# Altium schematic sheet cpu.SchDoc
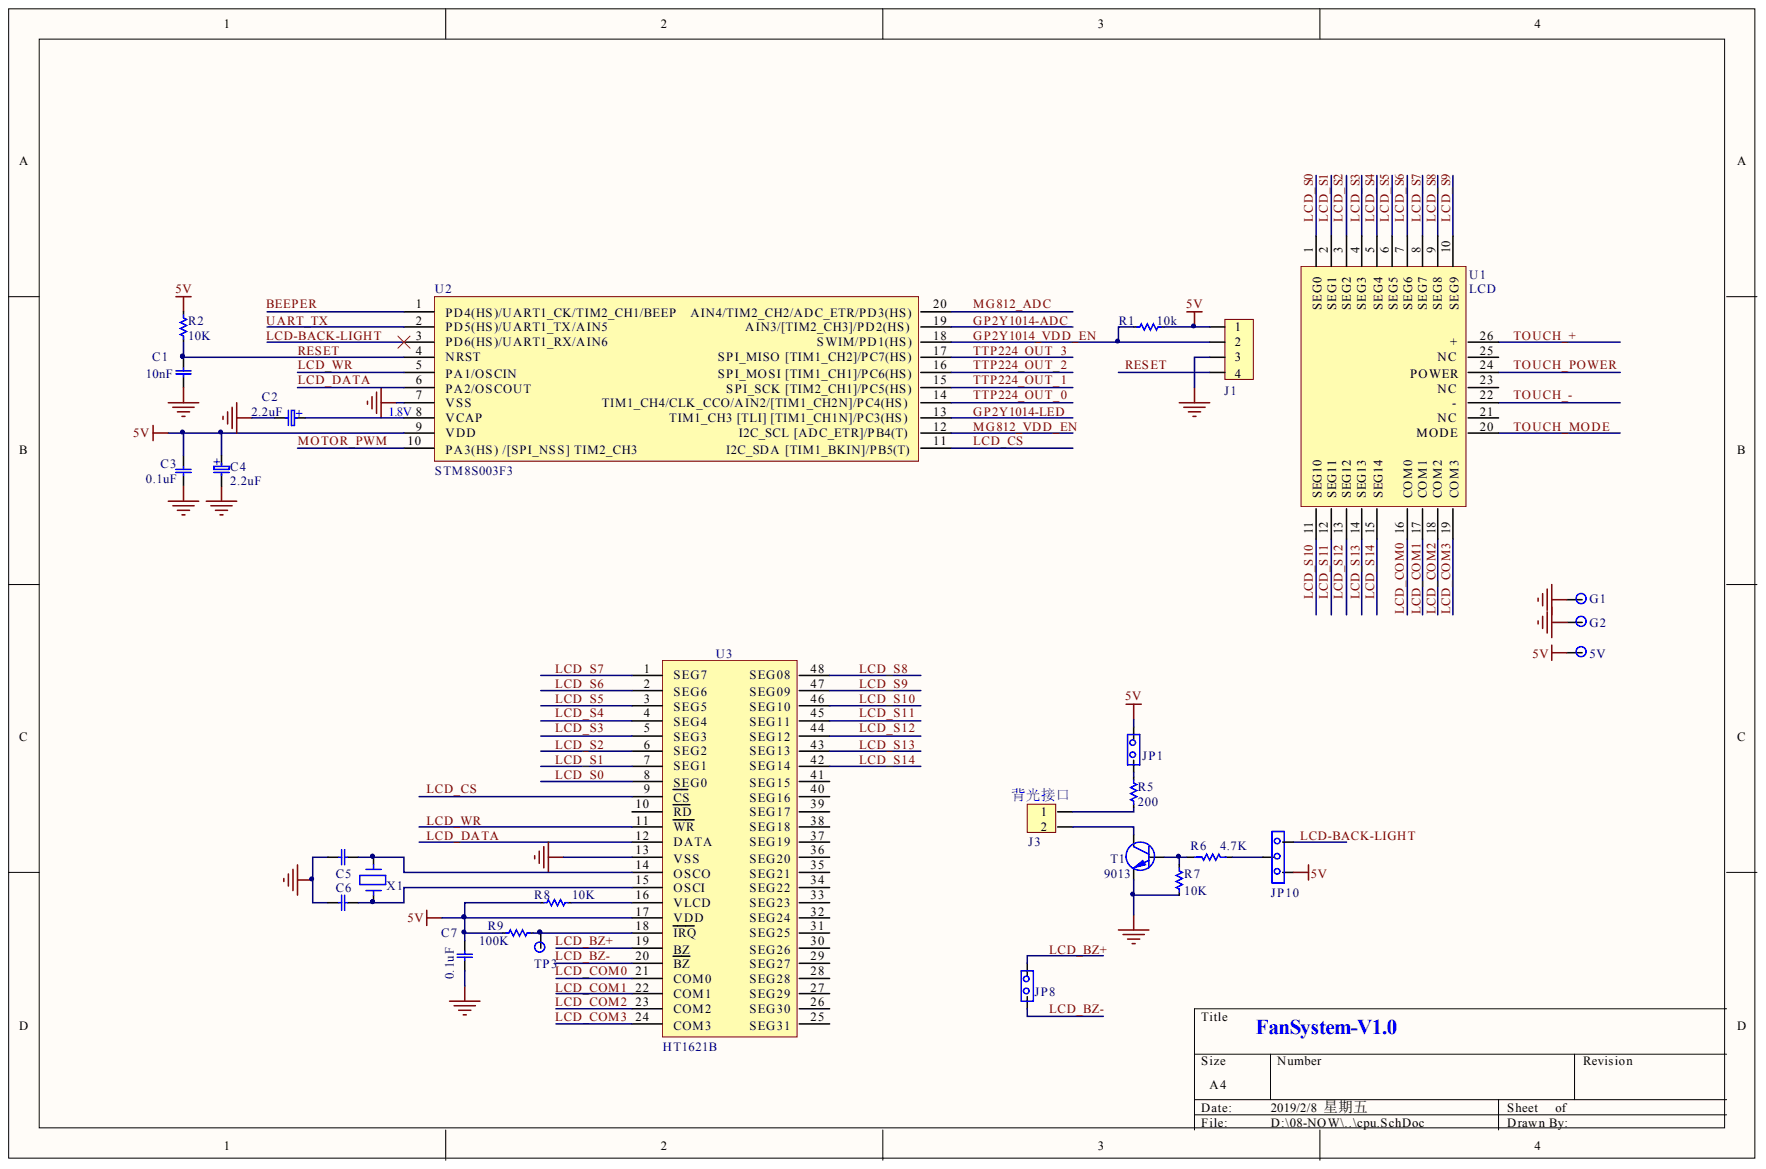
[diagram p0: cpu.SchDoc]

## Parts
5V  TP  (value not drawn)
C1  10nF
C2  2.2uF
C3  0.1uF
C4  2.2uF
C5  5pF  (value not drawn)
C6  5pF  (value not drawn)
C7  0.1uF
G1  TP  (value not drawn)
G2  TP  (value not drawn)
J1  CON4  (value not drawn)
J3  CON2  (value not drawn)
JP1  JP-2  (value not drawn)
JP8  JP-2  (value not drawn)
JP10  JP-3  (value not drawn)
R1  10k
R2  10K
R5  200
R6  4.7K
R7  10K
R8  10K
R9  100K
T1  9013
TP3  TP
U1  LCD
U2  STM8S003F3
U3  HT1621B
X1  32.728  (value not drawn)

## Nets
5V: 5V.1 C3.2 C4.A C7.2 J1.1 JP1.2 JP10.1 R1.2 R2.2 R8.1 R9.1 U2.9(VDD) U3.17(VDD)
GND: C1.1 C2.K C3.1 C4.K C5.1 C6.1 C7.1 G1.1 G2.1 J1.3 R7.1 T1.2 U2.7(VSS) U3.13(VSS)
BEEPER: U2.1(P_D_4(HS_)/U_A_RT1_CK/TIM2_CH1/BEEP)
GP2Y1014-ADC: U2.19(A_IN3/[TIM2_CH3]/P_D_2(HS_))
GP2Y1014-LED: U2.13(TIM1_CH3_[TLI]_[TIM1_CH1N]/P_C3(HS_))
GP2Y1014_VDD_EN: J1.2 R1.1 U2.18(S_WIM/P_D_1(HS_))
LCD-BACK-LIGHT: JP10.3 U2.3(P_D_6(HS_)/U_A_RT1_RX/A_IN6)
LCD_BZ+: JP8.2 U3.19(BZ)
LCD_BZ-: JP8.1 U3.20(BZ)
LCD_COM0: U1.16(CO_M_0) U3.21(COM_0)
LCD_COM1: U1.17(CO_M_1) U3.22(COM_1)
LCD_COM2: U1.18(CO_M_2) U3.23(COM_2)
LCD_COM3: U1.19(CO_M_3) U3.24(COM_3)
LCD_CS: U2.11([TIM1_BKIN]/P_B5(T)) U3.9(CS)
LCD_DATA: U2.6(P_A_2/O_S_COU_T) U3.12(D_A_TA)
LCD_S0: U1.1(S_EG_0) U3.8(S_EG_0)
LCD_S1: U1.2(S_EG_1) U3.7(S_EG_1)
LCD_S2: U1.3(S_EG_2) U3.6(S_EG_2)
LCD_S3: U1.4(S_EG_3) U3.5(S_EG_3)
LCD_S4: U1.5(S_EG_4) U3.4(S_EG_4)
LCD_S5: U1.6(S_EG_5) U3.3(S_EG_5)
LCD_S6: U1.7(S_EG_6) U3.2(S_EG_6)
LCD_S7: U1.8(S_EG_7) U3.1(S_EG_7)
LCD_S8: U1.9(S_EG_8) U3.48(S_EG_08)
LCD_S9: U1.10(S_EG_9) U3.47(S_EG_09)
LCD_S10: U1.11(S_EG_10) U3.46(S_EG_10)
LCD_S11: U1.12(S_EG_11) U3.45(S_EG_11)
LCD_S12: U1.13(S_EG_12) U3.44(S_EG_12)
LCD_S13: U1.14(S_EG_13) U3.43(S_EG_13)
LCD_S14: U1.15(S_EG_14) U3.42(S_EG_14)
LCD_WR: U2.5(P_A_1/O_S_CIN) U3.11(WR)
MG812_ADC: U2.20(A_IN4/TIM2_CH2/A_D_C_ETR/P_D_3(HS_))
MG812_VDD_EN: U2.12(I2C_SCL_[AD_C_ETR]/P_B4(T))
MOTOR_PWM: U2.10(P_A_3(HS_)_/[SP_I_NS_S_]_TIM2_CH3)
RESET: C1.2 J1.4 R2.1 U2.4(N_RST)
TOUCH_+: U1.26(+)
TOUCH_-: U1.22(-)
TOUCH_MODE: U1.20(MODE)
TOUCH_POWER: U1.24(P_O_WER)
TTP224_OUT_0: U2.14
TTP224_OUT_1: U2.15([TIM2_CH1]/P_C5(HS_))
TTP224_OUT_2: U2.16(S_P_I_MO_S_I_[TIM1_CH1]/P_C6(HS_))
TTP224_OUT_3: U2.17([TIM1_CH2]/P_C7(HS_))
UART_TX: U2.2(P_D_5(HS_)/U_A_RT1_TX_/A_IN5)
NetC2_A: C2.A U2.8(V_CAP)
NetC5_2: C5.2 U3.14(O_S_CO) X1.1
NetC6_2: C6.2 U3.15(O_S_CI) X1.2
NetJ3_1: J3.1 R5.1
NetJ3_2: J3.2 T1.3
NetJP1_1: JP1.1 R5.2
NetJP10_2: JP10.2 R6.1
NetR6_2: R6.2 R7.2 T1.1
NetR8_2: R8.2 U3.16(V_LCD)
NetR9_2: R9.2 TP3.1 U3.18(IRQ)

Not connected: U1 21(NC) 23(NC) 25(NC); U3 10(RD) 25(S_EG_31) 26(S_EG_30) 27(S_EG_29) 28(S_EG_28) 29(S_EG_27) 30(S_EG_26) 31(S_EG_25) 32(S_EG_24) 33(S_EG_23) 34(S_EG_22) 35(S_EG_21) 36(S_EG_20) 37(S_EG_19) 38(S_EG_18) 39(S_EG_17) 40(S_EG_16) 41(S_EG_15)
